# Altium schematic sheet STEPPER.SchDoc
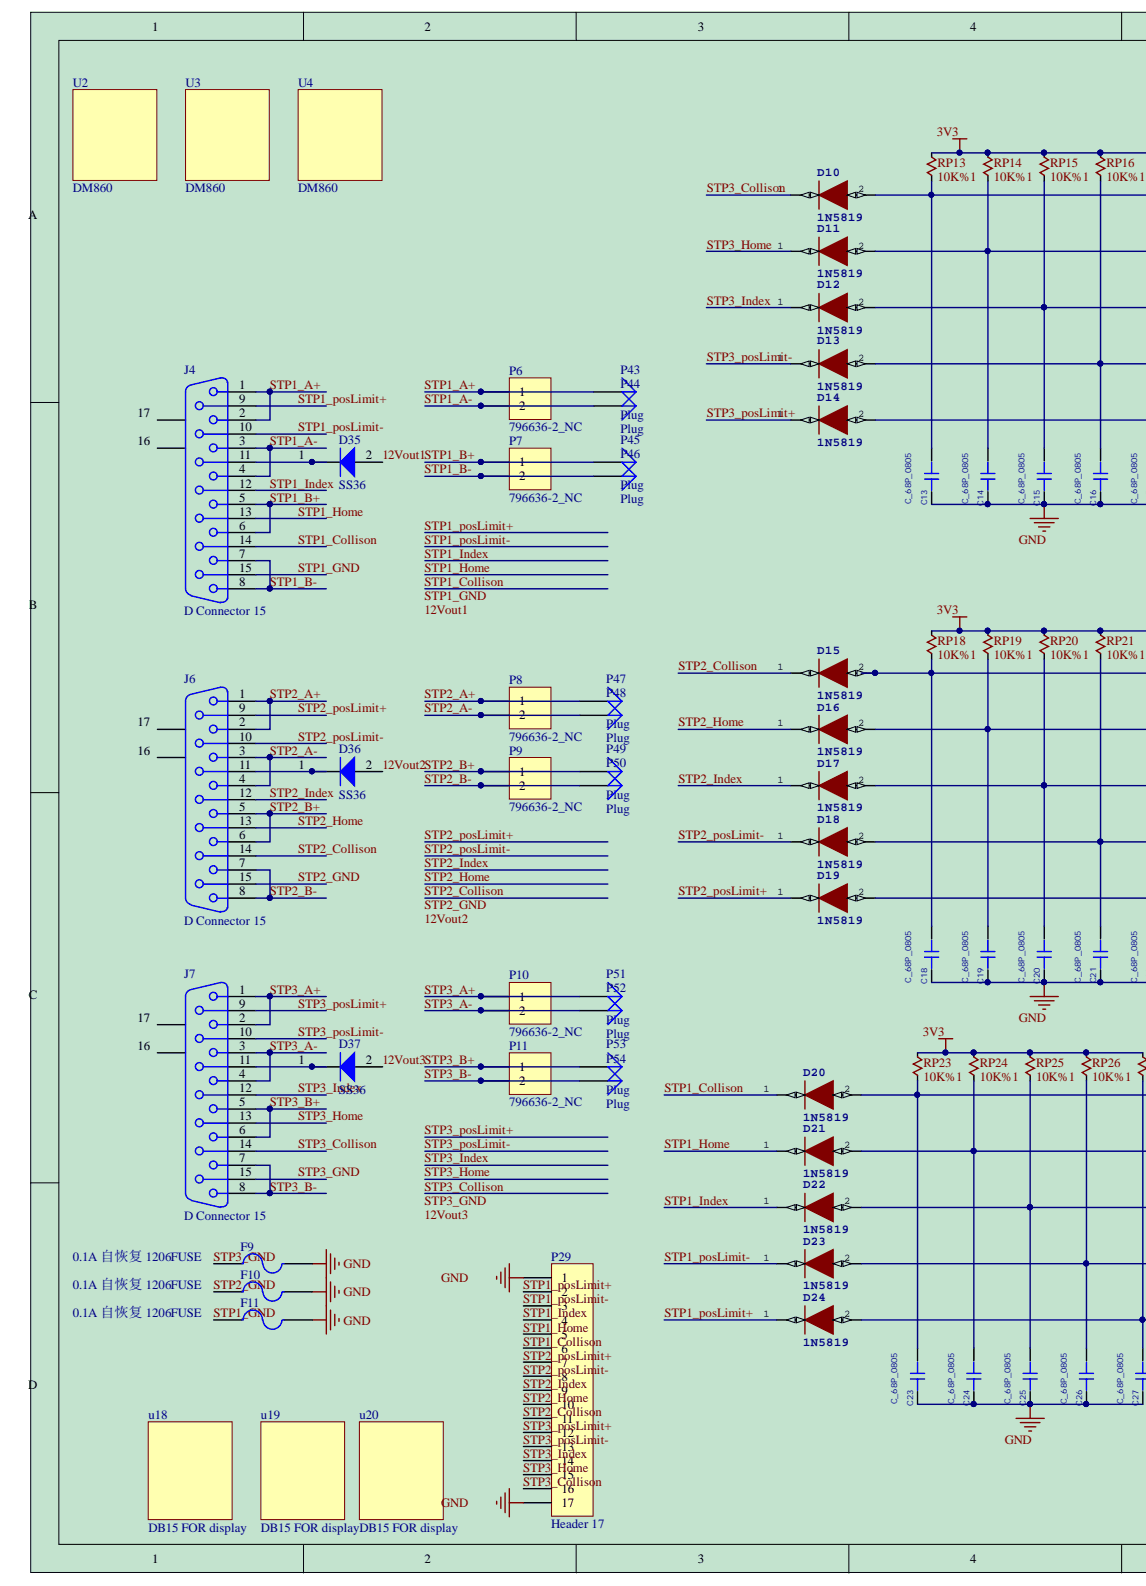
[diagram p0: STEPPER.SchDoc, left]
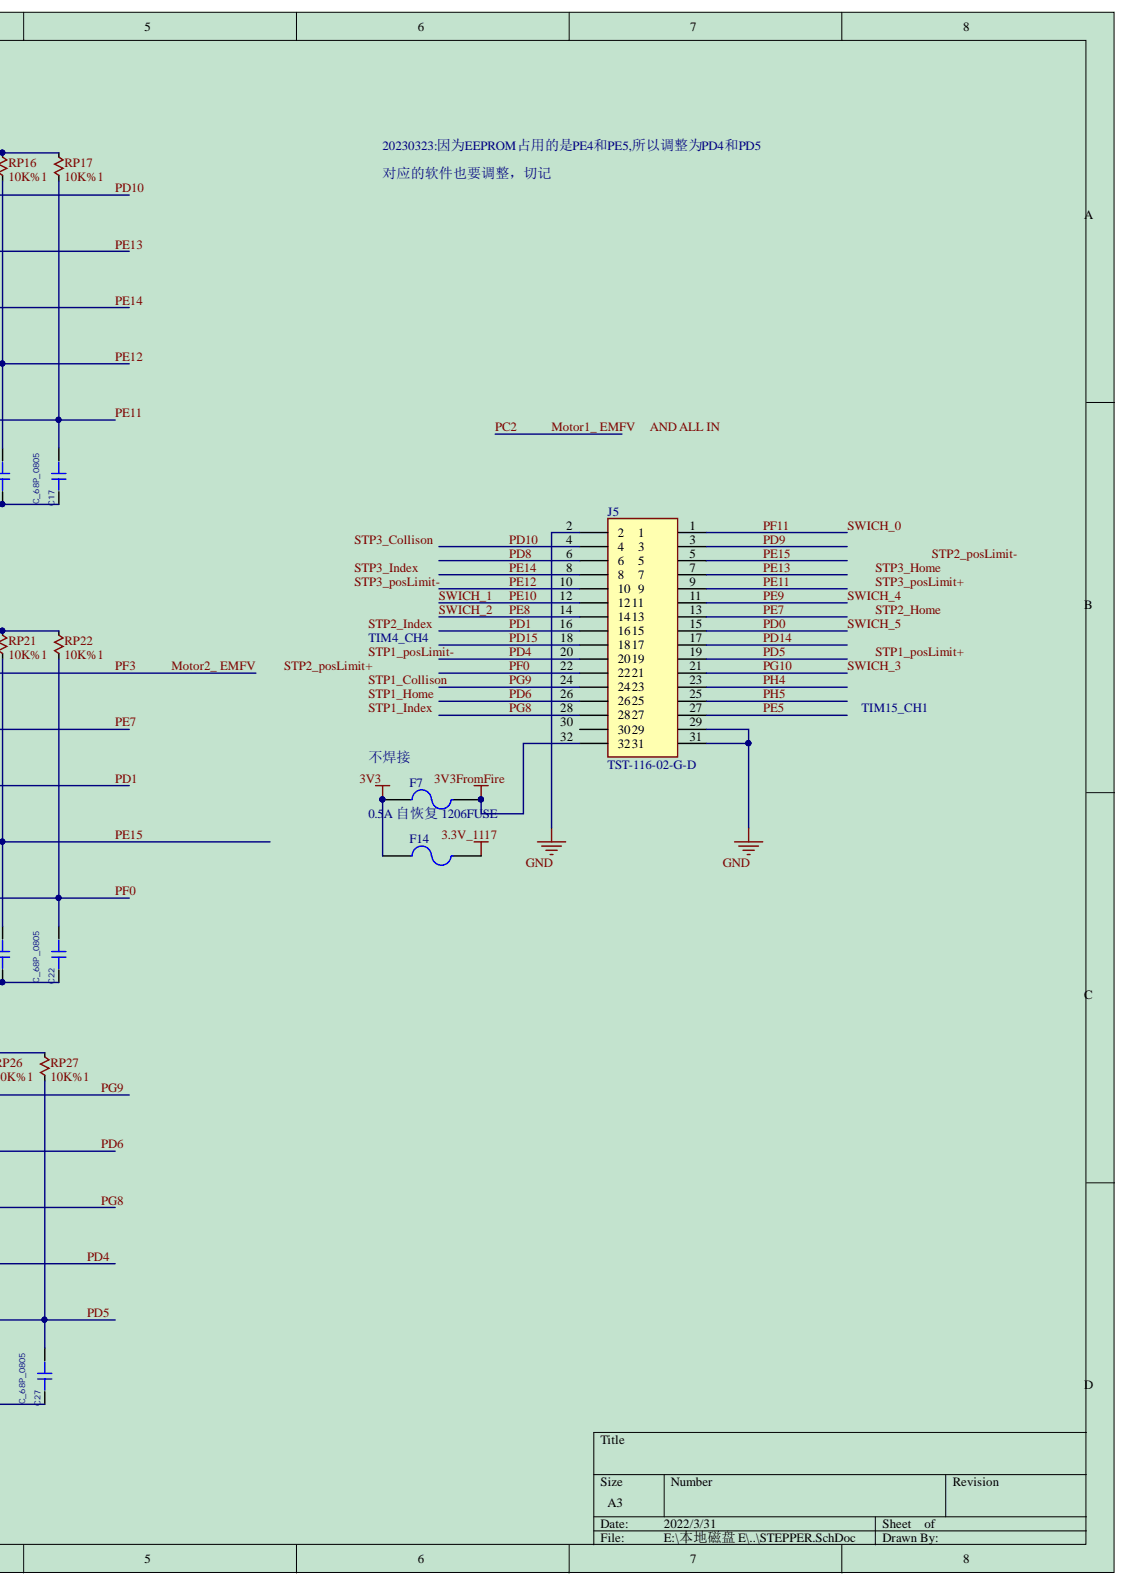
[diagram p1: STEPPER.SchDoc, right]

## Parts
C13  C_68P_0805  [cap0805]
C14  C_68P_0805  [cap0805]
C15  C_68P_0805  [cap0805]
C16  C_68P_0805  [cap0805]
C17  C_68P_0805  [cap0805]
C18  C_68P_0805  [cap0805]
C19  C_68P_0805  [cap0805]
C20  C_68P_0805  [cap0805]
C21  C_68P_0805  [cap0805]
C22  C_68P_0805  [cap0805]
C23  C_68P_0805  [cap0805]
C24  C_68P_0805  [cap0805]
C25  C_68P_0805  [cap0805]
C26  C_68P_0805  [cap0805]
C27  C_68P_0805  [cap0805]
D10  1N5819  [SOD123]
D11  1N5819  [SOD123]
D12  1N5819  [SOD123]
D13  1N5819  [SOD123]
D14  1N5819  [SOD123]
D15  1N5819  [SOD123]
D16  1N5819  [SOD123]
D17  1N5819  [SOD123]
D18  1N5819  [SOD123]
D19  1N5819  [SOD123]
D20  1N5819  [SOD123]
D21  1N5819  [SOD123]
D22  1N5819  [SOD123]
D23  1N5819  [SOD123]
D24  1N5819  [SOD123]
D35  SS36  [SMB_D]
D36  SS36  [SMB_D]
D37  SS36  [SMB_D]
F7  不焊接  [1206_FUSE]
F9  0.1A 自恢复 1206FUSE  [1206_FUSE]
F10  0.1A 自恢复 1206FUSE  [1206_FUSE]
F11  0.1A 自恢复 1206FUSE  [1206_FUSE]
F14  0.5A 自恢复 1206FUSE  [1206_FUSE]
J4  D Connector 15  [DB15_3D]
J5  TST-116-02-G-D  [TST-116-XX-Y-D]
J6  D Connector 15  [DB15_3D]
J7  D Connector 15  [DB15_3D]
P6  796636-2_NC  [pitch5082 _d_1.4_FINAL]
P7  796636-2_NC  [pitch5082 _d_1.4_FINAL]
P8  796636-2_NC  [pitch5082 _d_1.4_FINAL]
P9  796636-2_NC  [pitch5082 _d_1.4_FINAL]
P10  796636-2_NC  [pitch5082 _d_1.4_FINAL]
P11  796636-2_NC  [pitch5082 _d_1.4_FINAL]
P29  Header 17  [HDR1X17]
P43  Plug  [freePad2*10]
P44  Plug  [freePad2*10]
P45  Plug  [freePad2*10]
P46  Plug  [freePad2*10]
P47  Plug  [freePad2*10]
P48  Plug  [freePad2*10]
P49  Plug  [freePad2*10]
P50  Plug  [freePad2*10]
P51  Plug  [freePad2*10]
P52  Plug  [freePad2*10]
P53  Plug  [freePad2*10]
P54  Plug  [freePad2*10]
RP13  10K%1  [R0805]
RP14  10K%1  [R0805]
RP15  10K%1  [R0805]
RP16  10K%1  [R0805]
RP17  10K%1  [R0805]
RP18  10K%1  [R0805]
RP19  10K%1  [R0805]
RP20  10K%1  [R0805]
RP21  10K%1  [R0805]
RP22  10K%1  [R0805]
RP23  10K%1  [R0805]
RP24  10K%1  [R0805]
RP25  10K%1  [R0805]
RP26  10K%1  [R0805]
RP27  10K%1  [R0805]
U2  DM860  [DM860_pad]
U3  DM860  [DM860_pad]
U4  DM860  [DM860_pad]
u18  DB15 FOR display  [D15 SOCKET FOR DISPLAY -]
u19  DB15 FOR display  [D15 SOCKET FOR DISPLAY -]
u20  DB15 FOR display  [D15 SOCKET FOR DISPLAY -]

## Nets
3.3V_1117: F14.2
3V3: F7.1 F14.1 RP13.1 RP14.1 RP15.1 RP16.1 RP17.1 RP18.1 RP19.1 RP20.1 RP21.1 RP22.1 RP23.1 RP24.1 RP25.1 RP26.1 RP27.1
3V3FROMFIRE: F7.2 J5.32(32_31)
GND: C13.1 C14.1 C15.1 C16.1 C17.1 C18.1 C19.1 C20.1 C21.1 C22.1 C23.1 C24.1 C25.1 C26.1 C27.1 F9.2 F10.2 F11.2 J5.2 J5.29 J5.31 P29.1 P29.17
12VOUT1: D35.2
12VOUT2: D36.2
12VOUT3: D37.2
MOTOR2_ EMFV: C18.2 D15.2 RP18.2
PD0: J5.15
PD1: C20.2 D17.2 J5.16(16_15) RP20.2
PD4: C26.2 D23.2 J5.20(20_19) RP26.2
PD5: C27.2 D24.2 J5.19 RP27.2
PD6: C24.2 D21.2 J5.26(26_25) RP24.2
PD8: J5.6
PD9: J5.3
PD10: C13.2 D10.2 J5.4 RP13.2
PD14: J5.17
PD15: J5.18(18_17)
PE5: J5.27
PE7: C19.2 D16.2 J5.13 RP19.2
PE8: J5.14(14_13)
PE9: J5.11
PE10: J5.12(12_11)
PE11: C17.2 D14.2 J5.9 RP17.2
PE12: C16.2 D13.2 J5.10 RP16.2
PE13: C14.2 D11.2 J5.7 RP14.2
PE14: C15.2 D12.2 J5.8 RP15.2
PE15: C21.2 D18.2 J5.5 RP21.2
PF0: C22.2 D19.2 J5.22(22_21) RP22.2
PF11: J5.1
PG8: C25.2 D22.2 J5.28(28_27) RP25.2
PG9: C23.2 D20.2 J5.24(24_23) RP23.2
PG10: J5.21
PH4: J5.23
PH5: J5.25
STP1_A+: J4.1 J4.2 P6.1 P43.1
STP1_A-: J4.3 J4.4 P6.2 P44.1
STP1_B+: J4.5 J4.6 P7.1 P45.1
STP1_B-: J4.7 J4.8 P7.2 P46.1
STP1_COLLISON: D20.1 J4.14 P29.6
STP1_GND: F11.1 J4.15
STP1_HOME: D21.1 J4.13 P29.5
STP1_INDEX: D22.1 J4.12 P29.4
STP1_POSLIMIT+: D24.1 J4.9 P29.2
STP1_POSLIMIT-: D23.1 J4.10 P29.3
STP2_A+: J6.1 J6.2 P8.1 P47.1
STP2_A-: J6.3 J6.4 P8.2 P48.1
STP2_B+: J6.5 J6.6 P9.1 P49.1
STP2_B-: J6.7 J6.8 P9.2 P50.1
STP2_COLLISON: D15.1 J6.14 P29.11
STP2_GND: F10.1 J6.15
STP2_HOME: D16.1 J6.13 P29.10
STP2_INDEX: D17.1 J6.12 P29.9
STP2_POSLIMIT+: D19.1 J6.9 P29.7
STP2_POSLIMIT-: D18.1 J6.10 P29.8
STP3_A+: J7.1 J7.2 P10.1 P51.1
STP3_A-: J7.3 J7.4 P10.2 P52.1
STP3_B+: J7.5 J7.6 P11.1 P53.1
STP3_B-: J7.7 J7.8 P11.2 P54.1
STP3_COLLISON: D10.1 J7.14 P29.16
STP3_GND: F9.1 J7.15
STP3_HOME: D11.1 J7.13 P29.15
STP3_INDEX: D12.1 J7.12 P29.14
STP3_POSLIMIT+: D14.1 J7.9 P29.12
STP3_POSLIMIT-: D13.1 J7.10 P29.13
NetD35_1: D35.1 J4.11
NetD36_1: D36.1 J6.11
NetD37_1: D37.1 J7.11
AND ALL IN:
MOTOR1_ EMFV:

Not connected: J4 16 17; J5 30(30_29); J6 16 17; J7 16 17

## Library data of the parts
C13-C27 = C_22P_0603: PCB DECAL: C_0603; Component Kind: Standard
D10-D24 = 1N5819: Manufacturer_Name: STMicroelectronics; Component Kind: Standard; Height: mm; Library Name: Schlib1.SchLib; Manufacturer_Part_Number: 1N5819; Mouser_Part_Number: 511-1N5819; Mouser_Price-Stock: https://www.mouser.co.uk/ProductDetail/STMicroelectronics/1N5819?qs=G5AQjGfRJcKKUy9S%252BaTHRQ%3D%3D
D35-D37 = Diode 1N4150: High Conductance Ultra Fast Diode; Code - JEDEC: DO-204-AH; Revision 1: 11-Jul-2000: SIM Model Added.; Revision 2: 17-Jul-2002: Re-released for DXP Platform.; Component Kind: Standard; Datasheet: Latest Revision: 1997; Library Name: Miscellaneous Devices.IntLib; Package Reference: DO-35; Published: 8-Jun-2000; Signal Integrity: 1N4150; Simulation: 1N4150; Publisher: Altium Limited
F7 F9-F11 F14 = Fuse 2: Fuse; Component Kind: Standard; LatestRevisionDate: 17-Jul-2002; LatestRevisionNote: Re-released for DXP Platform.; PackageReference: PIN-W2/E2.8; Published: 8-Jun-2000; Simulation: FUSE; Library Name: jinrylib.IntLib; Publisher: Altium Limited
J4 J6 J7 = D Connector 15: Receptacle Assembly, 15 Position, Right Angle; PackageDocument: O; Positions: 15; Component Kind: Standard; DatasheetDocument: 1-Sep-99; Gender: Receptacle; LatestRevisionDate: 17-Jul-2002; LatestRevisionNote: Re-released for DXP Platform.; Library Name: Miscellaneous Connectors.IntLib; Orientation: Right Angle; PackageReference: 788757; Published: 15-Jun-2001; Signal Integrity: Connector; Publisher: Altium Limited
J5 = TST-116-02-G-D: Connector; Manufacturer_Name: SAMTEC; Component Kind: Standard; Datasheet Link: http://suddendocs.samtec.com/prints/tst-1xx-xx-x-x-xx-xx-mkt.pdf; Manufacturer_Part_Number: TST-116-02-G-D
P6-P11 = Header 2: Header, 2-Pin; Component Kind: Standard; Library Name: Miscellaneous Connectors.IntLib; Revision: 17-Jul-2002: Re-released for DXP Platform.; Signal Integrity: Connector; Publisher: Altium Limited
P29 = Header 17: Header, 17-Pin; Component Kind: Standard; LatestRevisionDate: 17-Jul-2002; LatestRevisionNote: Re-released for DXP Platform.; Library Name: Miscellaneous Connectors.IntLib; Signal Integrity: Connector; Publisher: Altium Limited
RP13-RP27 = RESISTOR: Generic Resistor; Value: 4.7K; Component Kind: Standard; Library Name: Digilent.IntLib
u18-u20 = Fire743: Component Kind: Standard; Library Name: Schlib1.SchLib
P43-P54 = Plug: Component Kind: Standard; Description: Plug; LatestRevisionDate: 17-Jul-2002; LatestRevisionNote: Re-released for DXP Platform.; Library Name: Miscellaneous Connectors.IntLib; Signal Integrity: Connector; Publisher: Altium Limited
U2-U4 = DM860: Component Kind: Standard; Library Name: Schlib1.SchLib
